# Altium schematic sheet LED_DRIVER.SchDoc
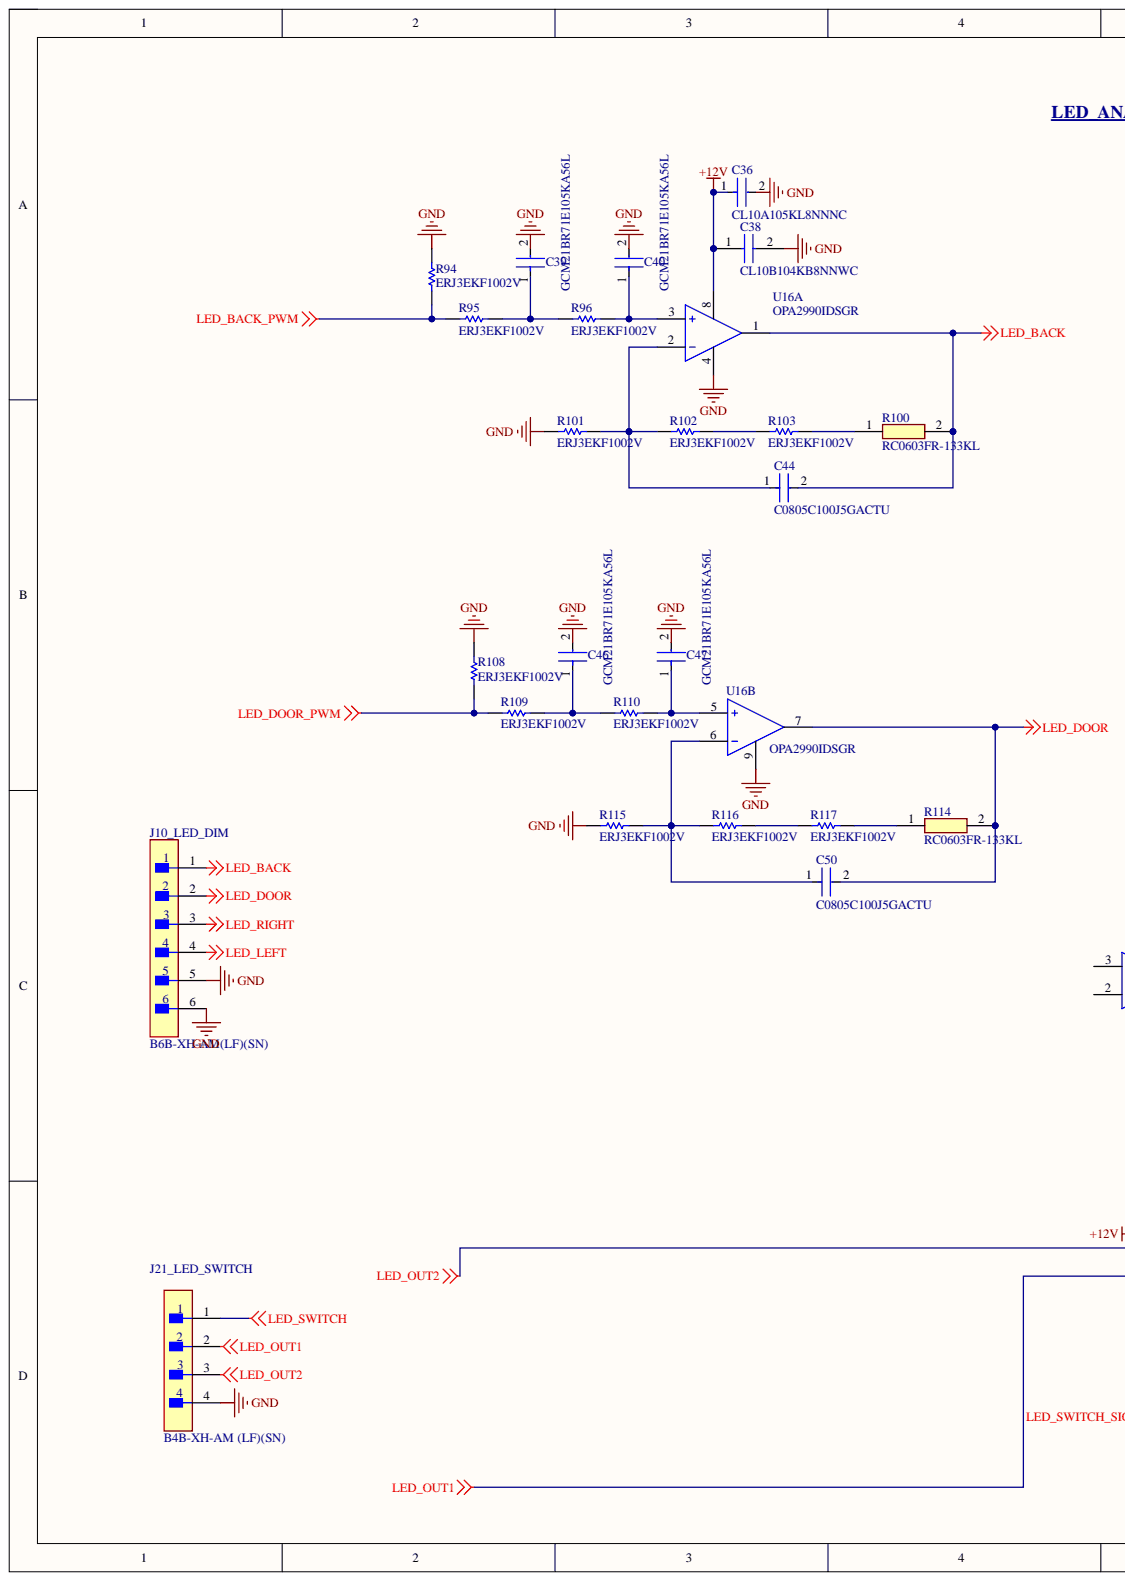
[diagram p0: LED_DRIVER.SchDoc, left]
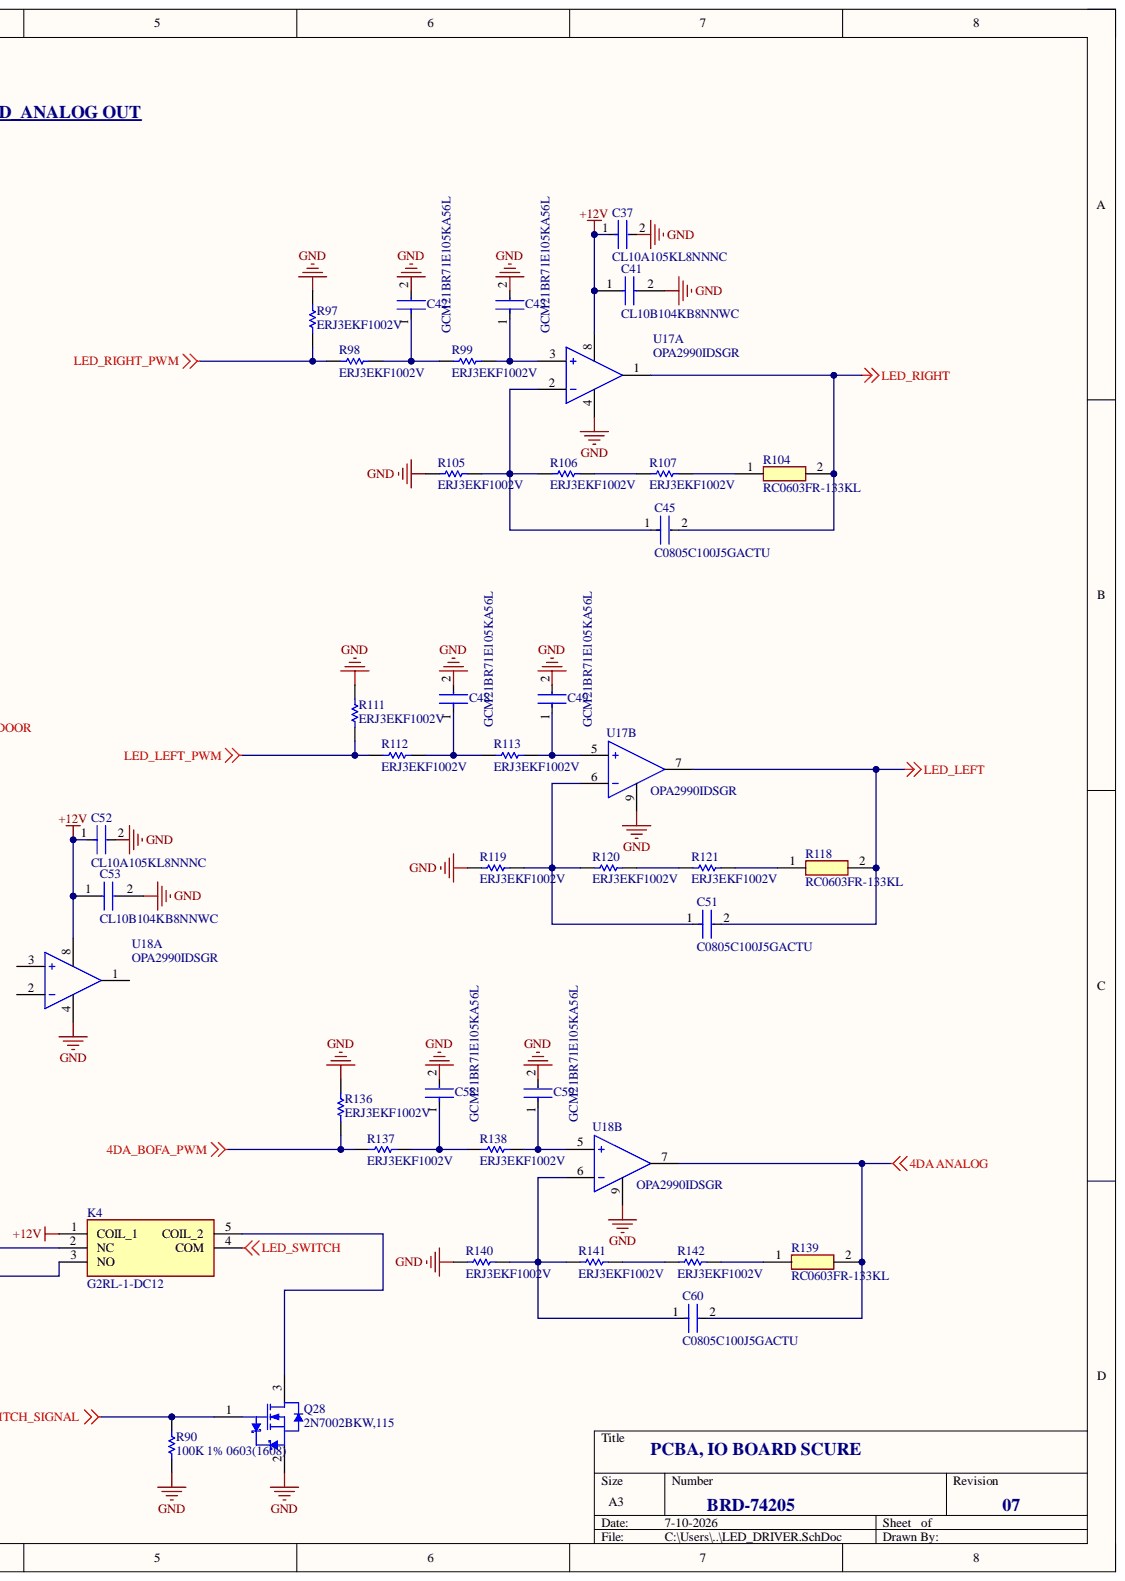
[diagram p1: LED_DRIVER.SchDoc, right]

## Parts
C36  CL10A105KL8NNNC  [FP-CL10-IPC_C]
C37  CL10A105KL8NNNC  [FP-CL10-IPC_C]
C38  CL10B104KB8NNWC  [CAPC1608X90N]
C39  GCM21BR71E105KA56L  [FP-GCM21B-0_15-MFG]
C40  GCM21BR71E105KA56L  [FP-GCM21B-0_15-MFG]
C41  CL10B104KB8NNWC  [CAPC1608X90N]
C42  GCM21BR71E105KA56L  [FP-GCM21B-0_15-MFG]
C43  GCM21BR71E105KA56L  [FP-GCM21B-0_15-MFG]
C44  C0805C100J5GACTU  [FP-C0805C-DN-MFG]
C45  C0805C100J5GACTU  [FP-C0805C-DN-MFG]
C46  GCM21BR71E105KA56L  [FP-GCM21B-0_15-MFG]
C47  GCM21BR71E105KA56L  [FP-GCM21B-0_15-MFG]
C48  GCM21BR71E105KA56L  [FP-GCM21B-0_15-MFG]
C49  GCM21BR71E105KA56L  [FP-GCM21B-0_15-MFG]
C50  C0805C100J5GACTU  [FP-C0805C-DN-MFG]
C51  C0805C100J5GACTU  [FP-C0805C-DN-MFG]
C52  CL10A105KL8NNNC  [FP-CL10-IPC_C]
C53  CL10B104KB8NNWC  [CAPC1608X90N]
C58  GCM21BR71E105KA56L  [FP-GCM21B-0_15-MFG]
C59  GCM21BR71E105KA56L  [FP-GCM21B-0_15-MFG]
C60  C0805C100J5GACTU  [FP-C0805C-DN-MFG]
J10_LED_DIM  B6B-XH-AM(LF)(SN)  [FP-B6B-XH-AM_LF_SN-MFG]
J21_LED_SWITCH  B4B-XH-AM (LF)(SN)  [FP-B4B-XH-AM_LF_SN-MFG]
K4  G2RL-1-DC12  [G2RL14]
Q28  2N7002BKW,115  [NXP-SOT323_N]
R90  100K 1% 0603(1608)  [RESC0603(1608)_L]
R94  ERJ3EKF1002V  [RESC1609X55X30LL15T15]
R95  ERJ3EKF1002V  [RESC1609X55X30LL15T15]
R96  ERJ3EKF1002V  [RESC1609X55X30LL15T15]
R97  ERJ3EKF1002V  [RESC1609X55X30LL15T15]
R98  ERJ3EKF1002V  [RESC1609X55X30LL15T15]
R99  ERJ3EKF1002V  [RESC1609X55X30LL15T15]
R100  RC0603FR-133KL  [RESC1608X55N]
R101  ERJ3EKF1002V  [RESC1609X55X30LL15T15]
R102  ERJ3EKF1002V  [RESC1609X55X30LL15T15]
R103  ERJ3EKF1002V  [RESC1609X55X30LL15T15]
R104  RC0603FR-133KL  [RESC1608X55N]
R105  ERJ3EKF1002V  [RESC1609X55X30LL15T15]
R106  ERJ3EKF1002V  [RESC1609X55X30LL15T15]
R107  ERJ3EKF1002V  [RESC1609X55X30LL15T15]
R108  ERJ3EKF1002V  [RESC1609X55X30LL15T15]
R109  ERJ3EKF1002V  [RESC1609X55X30LL15T15]
R110  ERJ3EKF1002V  [RESC1609X55X30LL15T15]
R111  ERJ3EKF1002V  [RESC1609X55X30LL15T15]
R112  ERJ3EKF1002V  [RESC1609X55X30LL15T15]
R113  ERJ3EKF1002V  [RESC1609X55X30LL15T15]
R114  RC0603FR-133KL  [RESC1608X55N]
R115  ERJ3EKF1002V  [RESC1609X55X30LL15T15]
R116  ERJ3EKF1002V  [RESC1609X55X30LL15T15]
R117  ERJ3EKF1002V  [RESC1609X55X30LL15T15]
R118  RC0603FR-133KL  [RESC1608X55N]
R119  ERJ3EKF1002V  [RESC1609X55X30LL15T15]
R120  ERJ3EKF1002V  [RESC1609X55X30LL15T15]
R121  ERJ3EKF1002V  [RESC1609X55X30LL15T15]
R136  ERJ3EKF1002V  [RESC1609X55X30LL15T15]
R137  ERJ3EKF1002V  [RESC1609X55X30LL15T15]
R138  ERJ3EKF1002V  [RESC1609X55X30LL15T15]
R139  RC0603FR-133KL  [RESC1608X55N]
R140  ERJ3EKF1002V  [RESC1609X55X30LL15T15]
R141  ERJ3EKF1002V  [RESC1609X55X30LL15T15]
R142  ERJ3EKF1002V  [RESC1609X55X30LL15T15]
U16  OPA2990IDSGR  [FP-DSG0008A-IPC_C]  (parts A,B)
U17  OPA2990IDSGR  [FP-DSG0008A-IPC_C]  (parts A,B)
U18  OPA2990IDSGR  [FP-DSG0008A-IPC_C]  (parts A,B)

## Nets
4DA ANALOG: C60.2 R139.2 U18.7
4DA_BOFA_PWM: R136.1 R137.1
+12V: C36.1 C37.1 C38.1 C41.1 C52.1 C53.1 K4.1(COIL_1) U16.8 U17.8 U18.8
GND: C36.2 C37.2 C38.2 C39.2 C40.2 C41.2 C42.2 C43.2 C46.2 C47.2 C48.2 C49.2 C52.2 C53.2 C58.2 C59.2 J10_LED_DIM.5 J10_LED_DIM.6 J21_LED_SWITCH.4 Q28.2 R90.1 R94.2 R97.2 R101.1 R105.1 R108.2 R111.2 R115.1 R119.1 R136.2 R140.1 U16.4 U16.9 U17.4 U17.9 U18.4 U18.9
LED_BACK: C44.2 J10_LED_DIM.1 R100.2 U16.1
LED_BACK_PWM: R94.1 R95.1
LED_DOOR: C50.2 J10_LED_DIM.2 R114.2 U16.7
LED_DOOR_PWM: R108.1 R109.1
LED_LEFT: C51.2 J10_LED_DIM.4 R118.2 U17.7
LED_LEFT_PWM: R111.1 R112.1
LED_OUT1: J21_LED_SWITCH.2 K4.3(NO)
LED_OUT2: J21_LED_SWITCH.3 K4.2(NC)
LED_RIGHT: C45.2 J10_LED_DIM.3 R104.2 U17.1
LED_RIGHT_PWM: R97.1 R98.1
LED_SWITCH: J21_LED_SWITCH.1 K4.4(COM)
LED_SWITCH_SIGNAL: Q28.1 R90.2
NetC39_1: C39.1 R95.2 R96.1
NetC40_1: C40.1 R96.2 U16.3
NetC42_1: C42.1 R98.2 R99.1
NetC43_1: C43.1 R99.2 U17.3
NetC44_1: C44.1 R101.2 R102.1 U16.2
NetC45_1: C45.1 R105.2 R106.1 U17.2
NetC46_1: C46.1 R109.2 R110.1
NetC47_1: C47.1 R110.2 U16.5
NetC48_1: C48.1 R112.2 R113.1
NetC49_1: C49.1 R113.2 U17.5
NetC50_1: C50.1 R115.2 R116.1 U16.6
NetC51_1: C51.1 R119.2 R120.1 U17.6
NetC58_1: C58.1 R137.2 R138.1
NetC59_1: C59.1 R138.2 U18.5
NetC60_1: C60.1 R140.2 R141.1 U18.6
NetK4_5: K4.5(COIL_2) Q28.3
NetR100_1: R100.1 R103.2
NetR102_2: R102.2 R103.1
NetR104_1: R104.1 R107.2
NetR106_2: R106.2 R107.1
NetR114_1: R114.1 R117.2
NetR116_2: R116.2 R117.1
NetR118_1: R118.1 R121.2
NetR120_2: R120.2 R121.1
NetR139_1: R139.1 R142.2
NetR141_2: R141.2 R142.1

Not connected: U18 1 2 3

## Library data of the parts
C36 C37 C52 = CMP-13271-002885-1: Cap Ceramic 1uF 35V X5R ±10% Pad SMD 0603 +85°C T/R; Capacitance: 1uF; Case Code (Imperial): 0603; Case Code (Metric): 1608; Case/Package: 0603; Component Kind: Standard; Contact Plating: Tin; Dielectric: X5R; Lead Free: Lead Free; Length: 0.063inch; Library Name: Altium Content Vault; Max Operating Temperature: 85°C; Min Operating Temperature: -55°C; Mount: Surface Mount; Package Quantity: 1; Packaging: Tape and Reel; Termination: Wraparound; Thickness: 0.035inch; Tolerance: 10%; Voltage Rating: 35V; Voltage Rating (DC): 35V; Width: 0.031inch
C38 C41 C53 = CL10B104KB8NNWC: Capacitor; Manufacturer_Name: SAMSUNG; Arrow Part Number: CL10B104KB8NNWC; Arrow Price/Stock: https://www.arrow.com/en/products/cl10b104kb8nnwc/samsung-electro-mechanics?region=europe; Component Kind: Standard; Datasheet Link: http://www.samsungsem.com/kr/support/product-search/mlcc/CL10B104KB8NNWC.jsp; Height: 0.9mm; Manufacturer_Part_Number: CL10B104KB8NNWC; Mouser Part Number: 187-CL10B104KB8NNWC; Mouser Price/Stock: https://www.mouser.co.uk/ProductDetail/Samsung-Electro-Mechanics/CL10B104KB8NNWC?qs=EvNa9vf1TdYOAZGBJN%252BGRQ%3D%3D
C39 C40 C42 C43 C46-C49 C58 C59 = CMP-2007-03346-2: Ceramic Capacitor for Automotive 1uF ±10% 25VDC X7R 0805 Embossed T/R; Voltage: 25V; Capacitance: 1uF; Case Code (Imperial): 0805; Case Code (Metric): 2012; Case/Package: 0805; Component Kind: Standard; Depth: 1.25mm; Dielectric: X7R; Height: 1.25mm; Lead Free: Lead Free; Length: 2mm; Library Name: Altium Content Vault; Max Operating Temperature: 125°C; Min Operating Temperature: -55°C; Mount: Surface Mount; Package Quantity: 3000; Packaging: Tape and Reel; Radiation Hardening: No; Ratings: AEC-Q200; REACH SVHC: No SVHC; Termination: SMD/SMT; Thickness: 0.055inch; Tolerance: 10%; Voltage Rating: 25V; Voltage Rating (DC): 25V; Width: 0.049inch
C44 C45 C50 C51 C60 = CMP-2007-00219-2: Capacitor; Ceramic; Cap 10 pF; Tol 5%; Vol-Rtg 50 VDC; SMT; 0805; COG (NPO); Tape&Reel; Manufacturer: KEMET; Capacitance: 10 pF; Temperature Characteristic: C0G; Temperature Coefficient: 30ppm/°C; Tolerance: 0.05; Value: 10 pF; Voltage Rating: 50 V; Automotive: No; Category: Cap; Component Kind: Standard; ComponentLink2Description: Datasheet; ComponentLink2URL: https://content.kemet.com/datasheets/KEM_C1003_C0G_SMD.pdf; Device Class L1: Passive Components; Device Class L2: Capacitors; Device Class L3: Ceramic Capacitors; Digikey Description: Capacitor; Ceramic; Cap 10 pF; Tol 5%; Vol-Rtg 50 VDC; SMT; 0805; COG (NPO); Tape&Reel; Height: 0.88mm; Ipc Land Pattern Name: CAPC200125X78; Lead Free: Yes; Library Name: Altium Content Vault; Manufacturer Part Number: C0805C100J5GACTU; Material: Ceramic; Mouser Description: KEMET - C0805C100J5GACTU - CAP, MLCC, C0G/NP0, 10PF, 50V, 0805; Octopart: https://octopart.com/c0805c100j5gactu-kemet-104357; Package: C0805C-DN; RoHS: TRUE; Temperature: -55°C to +125°C; Temperature Range High: +125°C; Temperature Range Low: -55°C
J10_LED_DIM = CMP-17439-000167-1: CONN HEADER VERT 6POS 2.5MM; Current Rating: 3A; Mated Stacking Heights: 9.8mm; Number of Poles: 6; Shape: Straight; Voltage Rating: 250V; Component Kind: Standard; Connector Type: Header; Contact Finish - Mating: TIN; Contact Material: Brass; Contact Plating: Copper; Contact Shape: Square; Contact Type: Male Pin; Fastening Type: Detent; Flammability Rating: UL94 V-0; Height: 7mm; Insulation Color: Natural; Insulation Material: Nylon; Length: 17.4mm; Library Name: Altium Content Vault; Max Operating Temperature: 85°C; Min Operating Temperature: -25°C; Mount: Through Hole; Number of Pins: 6; Number of Positions: 6; Number of Rows: 1; Packaging: Bulk; Pitch: 2.5mm; Series: XH; Termination: Press-Fit; Width: 5.75mm
J21_LED_SWITCH = CMP-17439-000117-1: CONN HEADER VERT 4POS 2.5MM; Contact Resistance: 20mR; Gender: Male; Mated Stacking Heights: 9.8mm; Max Current Rating: 3A; Max Voltage Rating (AC): 250V; Number of Positions: 4; Color: Green; Component Kind: Standard; Connector Type: Header; Contact Finish - Mating: TIN; Contact Material: Brass; Contact Plating: Copper; Contact Shape: Square; Contact Type: Male Pin; Depth: 5.75mm; Fastening Type: Detent; Flammability Rating: UL94 V-0; Housing Color: White; Housing Material: Polyamide; Insulation Color: Natural; Insulation Material: Nylon; Lead Free: Lead Free; Length: 12.4mm; Library Name: Altium Content Vault; Max Operating Temperature: 85°C; Min Operating Temperature: -25°C; Mount: Through Hole; Number of Pins: 4; Number of Rows: 1; Orientation: Straight; Packaging: Bulk; Pitch: 2.5mm; Series: XH; Termination: Solder; Voltage Rating: 250V
K4 = G2RL-1-DC12: Relay or Contactor; Manufacturer_Name: OMRON Electronics; Component Kind: Standard; Datasheet Link: https://omronfs.omron.com/en_US/ecb/products/pdf/en-g2rl.pdf; Manufacturer_Part_Number: G2RL-1-DC12; Mouser Part Number: 653-G2RL-1-DC12; Mouser Price/Stock: https://www.mouser.co.uk/ProductDetail/Omron-Electronics/G2RL-1-DC12?qs=kyocjfxn8IqpUEZvU5rsyg%3D%3D
Q28 = CMP-1259-00106-1: N-Channel Trench MOSFET, 60 V, 0.31 A, -55 to 150 degC, 3-Pin SOT323, Tape and Reel; Manufacturer: NXP Semiconductors; Automotive Qualified: Y; Channel Type: N; ID [max] (A): 0.31; IDM [max] (A): 1.2; Packing: Tape & Reel; VDS [max] (V): 60; VGSth [typ] (V): 1.6; Code_JEITA: SC-70; Component Kind: Standard; ComponentLink1Description: Manufacturer URL; ComponentLink1URL: http://www.nxp.com/; ComponentLink2Description: Datasheet; ComponentLink2URL: http://www.nxp.com/documents/data_sheet/2N7002BKW.pdf; ComponentLink3Description: Package Specification; ComponentLink3URL: http://www.nxp.com/documents/outline_drawing/sot323_po.pdf; DatasheetVersion: Rev. 1; Library Name: Altium Content Vault; Mounting Technology: Surface Mount; PackageDescription: 3-Pin Small Outline Transistor Package, Body 2 x 1.25 mm, Pitch 0.65 mm; PackageReference: SOT323; PackageVersion: 06-03-16, 03/2006; PartNumber: 2N7002BKW,115
R90 = CMP-1012-00736-1: 100K 0.1W 1% 0603 (1608 Metric)  SMD; Case-EIA: 0603; Case-Metric: 1608; Value: 100000.00 Ohm; Component Kind: Standard; Library Name: Altium Content Vault; Power: 0.1 W; Technology: SMT; Tolerance: 1 %
R94-R99 R101-R103 R105-R113 R115-R117 R119-R121 R136-R138 R140-R142 = CMP-1012-00623-3: Chip Resistor, 10 KOhm, +/- 1%, 0.1 W, -55 to 155 degC, 0603 (1608 Metric), RoHS, Tape and Reel; Manufacturer: Panasonic; Case\/Package: 0603; Max Power Dissipation: 100mW; Resistance: 10KOhm; Temperature Coefficient: 100ppm\/degreesC; Voltage Rating: 75V; Case Code (Imperial): 0603; Case Code (Metric): 1608; Component Kind: Standard; Composition: ThickFilm; Contact Plating: Tin; Datasheet URL: https://industrial.panasonic.com/cdbs/www-data/pdf/RDA0000/AOA0000C304.pdf; Datasheet Version: 02/2017; Depth: 0.8mm; Height: 0.45mm; Lead Free: LeadFree; Length: 1.6mm; Library Name: Altium Content Vault; Manufacturer URL: http://www.panasonic.com/; Max Operating Temperature: 155degC; Military Standard: Not; Min Operating Temperature: -55degC; Mount: SurfaceMount; Mounting Technology: SurfaceMount; Number of Pins: 2; Number of Terminations: 2; Package Description: 2-Pin Surface Mount Device, Body 1.6 x 0.85 mm; Package Quantity: 1; Package Reference: 603; Packaging: TapeandReel; Power Rating: 100mW; Radiation Hardening: No; REACH SVHC: NoSVHC; RoHSCompliant: true; Termination: SMD/SMT; Tolerance: 1%; Voltage Rating (DC): 75V; Width: 0.031inch
R100 R104 R114 R118 R139 = RC0603FR-133KL: Resistor; Manufacturer_Name: KEMET; Component Kind: Standard; Datasheet Link: http://www.yageo.com/documents/recent/PYu-RC0603_51_RoHS_L_v5.pdf; Height: 0.55mm; Manufacturer_Part_Number: RC0603FR-133KL; Mouser Part Number: 603-RC0603FR-133KL; Mouser Price/Stock: https://www.mouser.co.uk/ProductDetail/YAGEO/RC0603FR-133KL?qs=IuGqVx9wL0Kf3sfMECqRww%3D%3D
U16-U18 = CMP-04895-000291-2: IC OPAMP GP 2 CIRCUIT 8WSON; Component Kind: Standard; Library Name: Altium Content Vault
